# Altium schematic sheet lv_power_motor.SchDoc
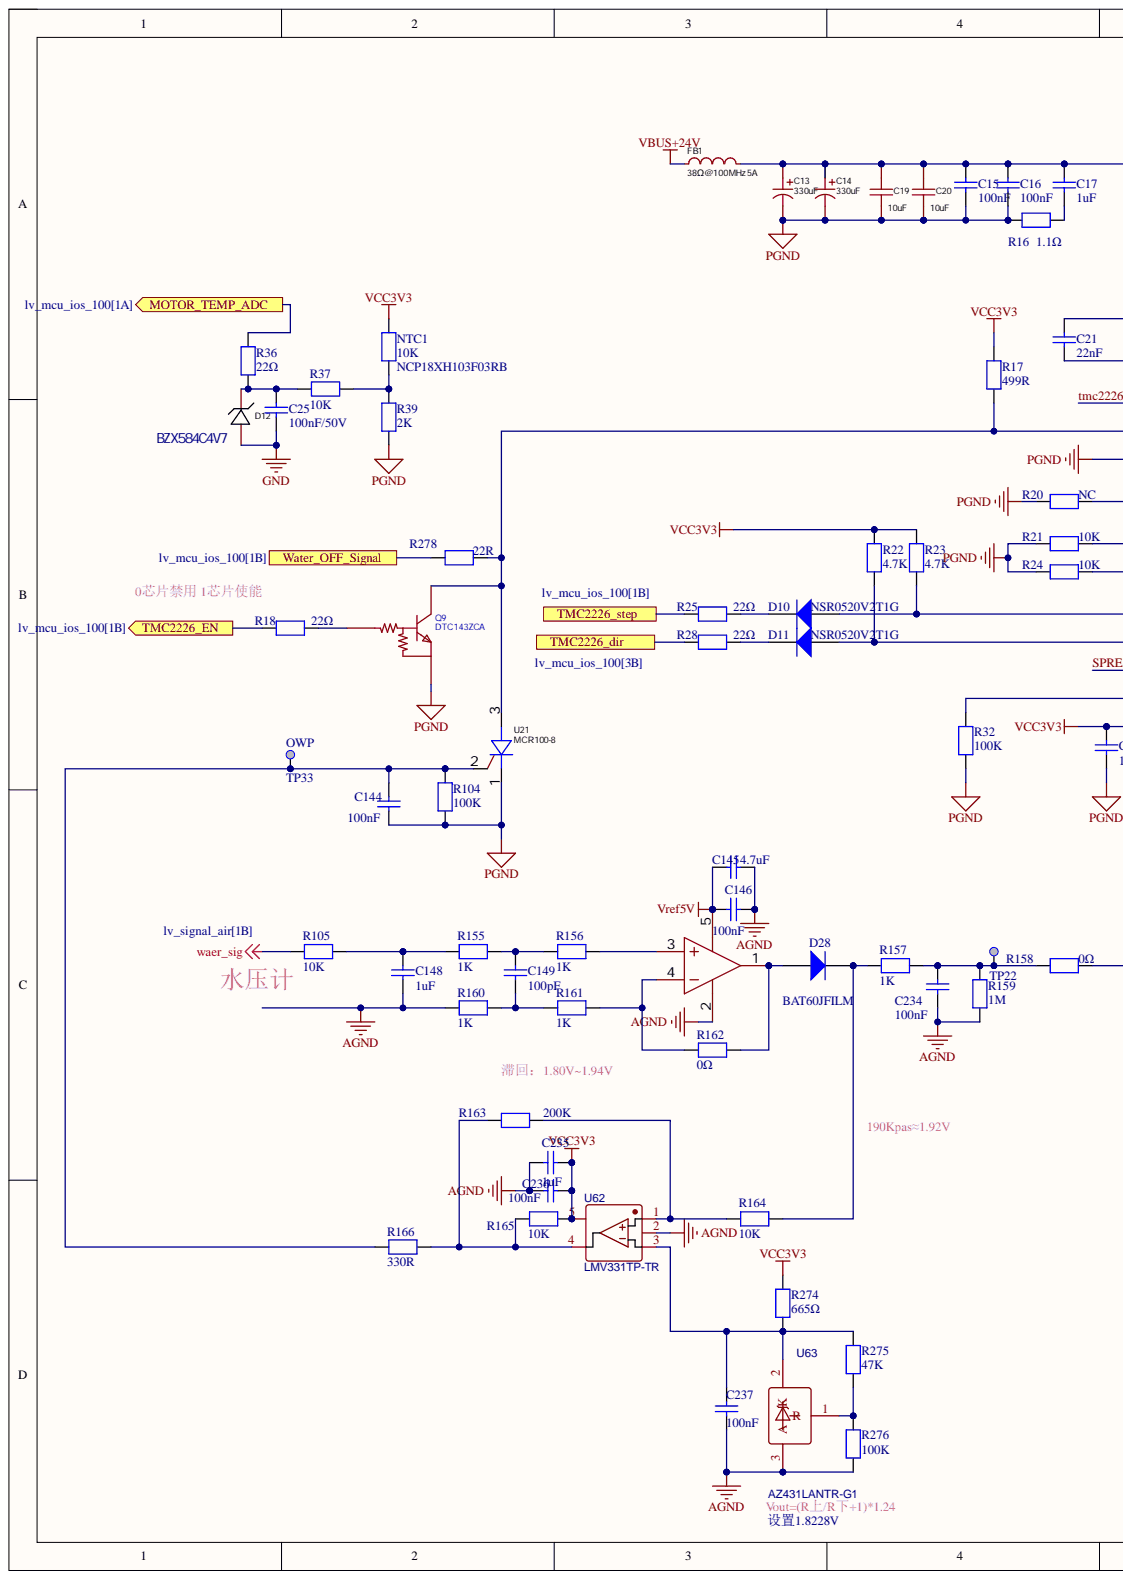
[diagram p0: lv_power_motor.SchDoc, left]
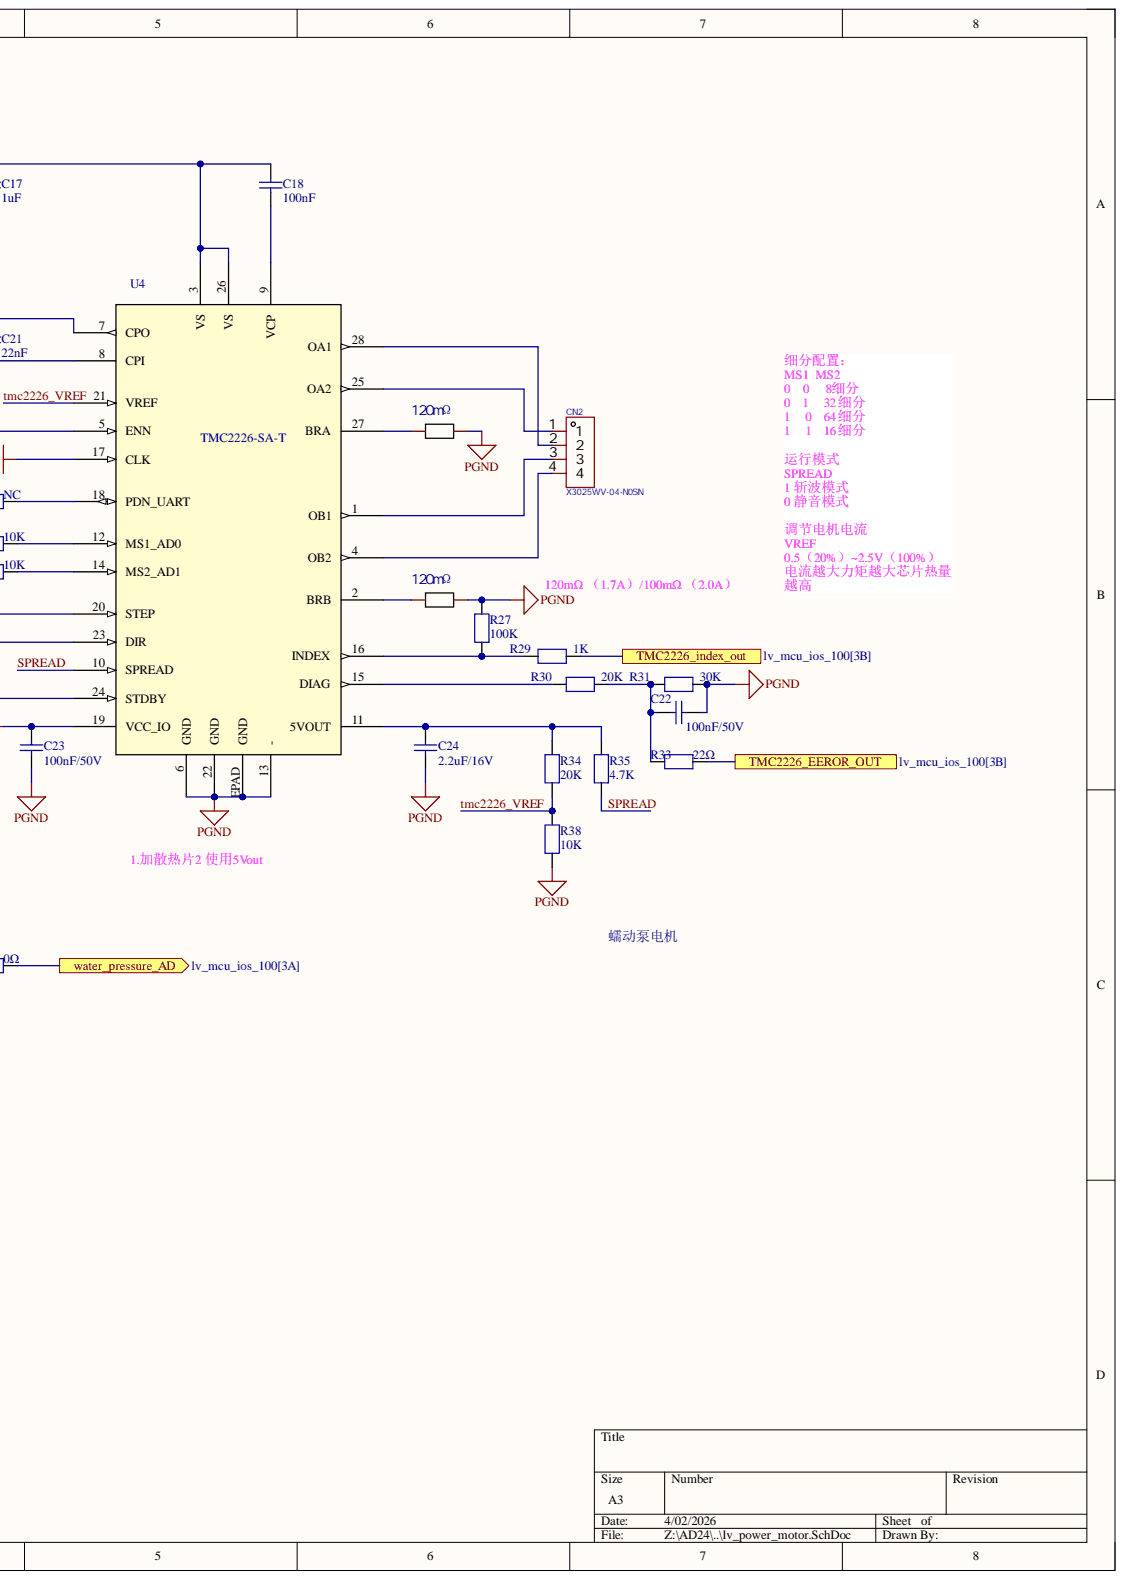
[diagram p1: lv_power_motor.SchDoc, right]

## Parts
C13  330uF  [CAP-SMD_BD10.0-L10.3-W10.3-LS10.9-FD]
C14  330uF  [CAP-SMD_BD10.0-L10.3-W10.3-LS10.9-FD]
C15  100nF  [C0603]
C16  100nF  [C0603]
C17  1uF  [C1210]
C18  100nF  [C0603]
C19  10uF  [C2220]
C20  10uF  [C2220]
C21  22nF  [C0603]
C22  100nF/50V  [C0402]
C23  100nF/50V  [C0402]
C24  2.2uF/16V  [C0603]
C25  100nF/50V  [C0402]
C144  100nF  [C0402]
C145  4.7uF  [C0603]
C146  100nF  [C0402]
C148  1uF  [C0603]
C149  100pF  [C0402]
C234  100nF  [C0402]
C235  value 1uF  [C0603]
C236  100nF  [C0402]
C237  100nF  [C0402]
CN2  X3025WV-04-N0SN  [CONN-TH_4P-P3.00_X3025WV-04-N0SN]
D10  NSR0520V2T1G  [SOD-523F_L1.2-W0.8-LS1.7-RD]
D11  NSR0520V2T1G  [SOD-523F_L1.2-W0.8-LS1.7-RD]
D12  BZX584C4V7  [SOD-523F_L1.2-W0.8-LS1.7-RD]
D28  BAT60JFILM  [DIO_SOD323]
FB1  LCSC Part Name: 38Ω@100MHz 5A  [L1206]  (hidden comment: PZ3216D380-5R0TF)
NTC1  NCP18XH103F03RB  value 10K  [R0603]
Q9  DTC143ZCA  [SOT-416_L1.6-W0.8-P1.00-LS1.6-BR]
R16  1.1Ω  [R0603]
R17  499R  [R0603]
R18  22Ω  [R0402]
R19  120mΩ  [RES-SMD_L6.4-W3.2_C500733]
R20  NC  [R0402]
R21  10K  [R0402]
R22  4.7K  [R0402]
R23  4.7K  [R0402]
R24  10K  [R0402]
R25  22Ω  [R0402]
R26  120mΩ  [RES-SMD_L6.4-W3.2_C500733]
R27  100K  [R0402]
R28  22Ω  [R0402]
R29  1K  [R0402]
R30  20K  [R0402]
R31  30K  [R0402]
R32  100K  [R0603]
R33  22Ω  [R0402]
R34  20K  [R0402]
R35  4.7K  [R0402]
R36  22Ω  [R0402]
R37  10K  [R0402]
R38  10K  [R0402]
R39  2K  [R0402]
R104  100K  [R0402]
R105  10K  [R0402]
R155  1K  [R0402]
R156  1K  [R0402]
R157  1K  [R0402]
R158  0Ω  [R0402]
R159  1M  [R0402]
R160  1K  [R0402]
R161  1K  [R0402]
R162  0Ω  [R0402]
R163  200K  [R0402]
R164  10K  [R0402]
R165  10K  [R0402]
R166  330R  [R0402]
R274  665Ω  [R0402]
R275  47K  [R0402]
R276  100K  [R0402]
R278  22R  [R0402]
TP22  WaterADC  [TP_SMD_1MM]  (value not drawn)  (no BOM)
TP33  OWP  [TP_SMD_1MM]  (no BOM)
U4  TMC2226-SA-T  [HTSSOP_26-SA-T_TRI]
U21  MCR100-8  [SOT-23-3_L2.9-W1.3-P1.90-LS2.4-BR]
U60  TLV271CDBVR  [SOT-23-5_L3.0-W1.7-P0.95-LS2.8-BR]  (value not drawn)
U62  LMV331TP-TR  [SOT-23-5_L3.0-W1.7-P0.95-LS2.8-BR]
U63  AZ431LANTR-G1  [SOT-23-3_L2.9-W1.6-P1.90-LS2.8-BR]

## Ports
MOTOR_TEMP_ADC, TMC2226_dir, TMC2226_EEROR_OUT, TMC2226_EN, TMC2226_index_out, TMC2226_step, Water_OFF_Signal, water_pressure_AD

## Nets
AGND: C145.1 C146.2 C148.2 C234.1 C235.1 C236.2 C237.2 R159.1 R160.1 R276.1 U60.2 U62.2 U63.3
GND: C25.2 D12.2
PGND: C13.2 C14.2 C15.1 C16.1 C19.2 C20.2 C22.2 C23.2 C24.2 C144.2 Q9.2 R16.2 R19.2 R20.2 R21.1 R24.1 R26.2 R27.1 R31.1 R32.1 R38.2 R39.1 R104.1 U4.6(GND) U4.13(-) U4.17(CLK) U4.22(GND) U4.EPAD(GND) U21.1
VBUS+24V: FB1.1
VCC3V3: C23.1 C235.2 C236.1 NTC1.2 R17.2 R22.1 R23.1 R165.2 R274.2 U4.19(VCC_IO) U62.5
Vref5V: C145.2 C146.1 U60.5
waer_sig: R105.1
MOTOR_TEMP_ADC: R36.2 ; port MOTOR_TEMP_ADC
SPREAD: R35.2 U4.10(SPREAD)
TMC2226_dir: R28.1 ; port TMC2226_dir
TMC2226_EEROR_OUT: R33.2 ; port TMC2226_EEROR_OUT
TMC2226_EN: R18.1 ; port TMC2226_EN
TMC2226_index_out: R29.1 ; port TMC2226_index_out
TMC2226_step: R25.1 ; port TMC2226_step
tmc2226_VREF: R34.2 R38.1 U4.21(VREF)
Water_OFF_Signal: R278.2 ; port Water_OFF_Signal
water_pressure_AD: R158.1 ; port water_pressure_AD
NetC13_1: C13.1 C14.1 C15.2 C16.2 C17.2 C18.2 C19.1 C20.1 FB1.2 U4.3(VS) U4.26(VS)
NetC17_1: C17.1 R16.1
NetC18_1: C18.1 U4.9(VCP)
NetC21_1: C21.1 U4.8(CPI)
NetC21_2: C21.2 U4.7(CPO)
NetC22_1: C22.1 R30.1 R31.2 R33.1
NetC24_1: C24.1 R34.1 R35.1 U4.11(5VOUT)
NetC25_1: C25.1 D12.1 R36.1 R37.2
NetC144_1: C144.1 R104.2 R166.1 TP33.1 U21.2
NetC148_1: C148.1 R105.2 R155.1
NetC149_1: C149.1 R155.2 R156.1
NetC149_2: C149.2 R160.2 R161.1
NetC234_2: C234.2 R157.2 R158.2 R159.2 TP22.1
NetC237_1: C237.1 R274.1 R275.2 U62.3 U63.2
NetCN2_1: CN2.1 U4.25(OA2)
NetCN2_2: CN2.2 U4.28(OA1)
NetCN2_3: CN2.3 U4.1(OB1)
NetCN2_4: CN2.4 U4.4(OB2)
NetD10_1: D10.1 R25.2
NetD10_2: D10.2 R23.2 U4.20(STEP)
NetD11_1: D11.1 R28.2
NetD11_2: D11.2 R22.2 U4.23(DIR)
NetD28_1: D28.1 R162.1 U60.1
NetD28_2: D28.2 R157.1 R164.1
NetNTC1_1: NTC1.1 R37.1 R39.2
NetQ9_1: Q9.1 R18.2
NetQ9_3: Q9.3 R17.1 R278.1 U4.5(ENN) U21.3
NetR19_1: R19.1 U4.27(BRA)
NetR20_1: R20.1 U4.18(PDN_UART)
NetR21_2: R21.2 U4.12(MS1_AD0)
NetR24_2: R24.2 U4.14(MS2_AD1)
NetR26_1: R26.1 U4.2(BRB)
NetR27_2: R27.2 R29.2 U4.16(INDEX)
NetR30_2: R30.2 U4.15(DIAG)
NetR32_2: R32.2 U4.24(STDBY)
NetR156_2: R156.2 U60.3
NetR161_2: R161.2 R162.2 U60.4
NetR163_1: R163.1 R164.2 U62.1
NetR163_2: R163.2 R165.1 R166.2 U62.4
NetR275_1: R275.1 R276.2 U63.1

## Library data of the parts
C13 C14 = HV1V337M1010PZ: 330uF, 35V, 20%; Manufacturer: HONOR(荣誉); Add into BOM: yes; L: 10mm; Component Kind: Standard; Convert to PCB: yes; Datasheet: https://atta.szlcsc.com/upload/public/pdf/source/20240802/61683F5000C7CFED5606ABBE3CFBE0F5.pdf; Device: HV1V337M1010PZ; JLCPCB Part Class: Extended Part; LCSC Part Name: 330uF ±20% 35V; Library Name: HiPin_Library_AD.IntLib; Manufacturer Part: HV1V337M1010PZ; Operating Temperature: -55℃~+105℃; Rated Voltage: 35V; Supplier: LCSC; Supplier Footprint: SMD,D10xL10mm; Supplier Part: C5246558; Symbol: HV1V337M1010PZ; Tolerance: ±20%; Value: 330uF; φD: 10mm
C15 C16 C18 = Capacitor_1: 50V, X7R, 10%; Manufacturer: muRata; Manufacturer_Part_Number: GRM188R71H104KA93D; Component Kind: Standard; ComponentLink1Description: Yageo_Capacitor_X5R-series.pdf; ComponentLink1URL: http://tunmcdsvn.tun.st.com/Component_Datasheet_Library/Trunk/Capacitors/Yageo_Multilayer_capacitor_X5R_series_100pF_to_100uF; Library Name: HiPin_Library_AD.IntLib
C17 = Capacitor_3: 50V, X7R, 10%; Manufacturer: YAGEO; Manufacturer_Part_Number: CC1210KKX7R9BB105; Component Kind: Standard; Library Name: HiPin_Library_AD.IntLib
C19 C20 = FS55X106K500EGG: Manufacturer: PSA(信昌电陶); Add into BOM: yes; Temperature Coefficient: X7R; Tolerance: ±10%; Voltage Rated: 50V; Component Kind: Standard; Convert to PCB: yes; Datasheet: https://atta.szlcsc.com/upload/public/pdf/source/20190723/C381466_B1CCDB289216E7EE775C98769E222CFD.pdf; Device: FS55X106K500EGG; JLCPCB Part Class: Extended Part; LCSC Part Name: 10uF ±10% 50V; Library Name: HiPin_Library_AD.IntLib; Manufacturer Part: FS55X106K500EGG; Supplier: LCSC; Supplier Footprint: 2220; Supplier Part: C153034; Symbol: FS55X106K500EGG; Value: 10uF
C21 = Capacitor_1: 50V, X7R, 10%; Manufacturer: muRata; Manufacturer_Part_Number: GCJ188R71H223KA01D; Component Kind: Standard; ComponentLink1Description: Yageo_Capacitor_X5R-series.pdf; ComponentLink1URL: http://tunmcdsvn.tun.st.com/Component_Datasheet_Library/Trunk/Capacitors/Yageo_Multilayer_capacitor_X5R_series_100pF_to_100uF; Library Name: HiPin_Library_AD.IntLib
C22 C23 C25 = Capacitor_2: 50V, X7R , 10%; Manufacturer: muRata; Manufacturer_Part_Number: GRM155R71H104KE14D; Component Kind: Standard; ComponentLink1Description: Datasheet; ComponentLink1URL: http://tunmcdsvn.tun.st.com/Component_Datasheet_Library/Trunk/Capacitors/Yageo_Multilayer_capacitor_X5R_series_100pF_to_100uF; Library Name: HiPin_Library_AD.IntLib
C24 = Capacitor_2: 16V, X7R , 10%; Manufacturer: YAGEO; Manufacturer_Part_Number: CC0603KRX7R7BB225; Component Kind: Standard; ComponentLink1Description: Datasheet; ComponentLink1URL: http://tunmcdsvn.tun.st.com/Component_Datasheet_Library/Trunk/Capacitors/Yageo_Multilayer_capacitor_X5R_series_100pF_to_100uF; Library Name: HiPin_Library_AD.IntLib
C144 C236 C237 = Capacitor: 50V, 10%, X7R; Internal_Part_Number: MCD-00003; Manufacturer: muRata; Manufacturer_Part_Number: GRM155R71H104KE14D; Component Kind: Standard; ComponentLink1Description: Datasheet; ComponentLink1URL: http://tunmcdsvn.tun.st.com/Component_Datasheet_Library/Trunk/Capacitors/Yageo_Capacitor_NP0_16V-to-50V; Library Name: HiPin_Library_AD.IntLib; Package: C0402; Value: 100nF
C145 = Capacitor_1: 16V, X7S, 10%; Internal_Part_Number: MCD-00009; Manufacturer: muRata; Manufacturer_Part_Number: GRM188C71C475KE21D; Component Kind: Standard; ComponentLink1Description: Yageo_Capacitor_X5R-series.pdf; ComponentLink1URL: http://tunmcdsvn.tun.st.com/Component_Datasheet_Library/Trunk/Capacitors/Yageo_Multilayer_capacitor_X5R_series_100pF_to_100uF; Library Name: HiPin_Library_AD.IntLib; Package: C0603; Value: 4.7uF
C146 C234 = Capacitor: 50V, 5%, X7R; Manufacturer: muRata; Manufacturer_Part_Number: GRM155R71H104KE14D; Component Kind: Standard; ComponentLink1Description: Datasheet; ComponentLink1URL: http://tunmcdsvn.tun.st.com/Component_Datasheet_Library/Trunk/Capacitors/Yageo_Capacitor_NP0_16V-to-50V; Library Name: HiPin_Library_AD.IntLib
C148 = Capacitor_1: 50V, X5R, 10%; Manufacturer: muRata; Manufacturer_Part_Number: GRM188R61H105KAALD; Component Kind: Standard; ComponentLink1Description: Yageo_Capacitor_X5R-series.pdf; ComponentLink1URL: http://tunmcdsvn.tun.st.com/Component_Datasheet_Library/Trunk/Capacitors/Yageo_Multilayer_capacitor_X5R_series_100pF_to_100uF; Library Name: HiPin_Library_AD.IntLib
C149 = Capacitor: 50V, 5%, C0G; Manufacturer: muRata; Manufacturer_Part_Number: GRM1555C1H101JA01D; Component Kind: Standard; ComponentLink1Description: Datasheet; ComponentLink1URL: http://tunmcdsvn.tun.st.com/Component_Datasheet_Library/Trunk/Capacitors/Yageo_Capacitor_NP0_16V-to-50V; Library Name: HiPin_Library_AD.IntLib
C235 = MCD-00010: Capacitor, MLCC, 1uF, 16V, X5R, 10%, 0603, T=0,8mm; Manufacturer: YAGEO; Manufacturer_Part_Number: CC0603KRX5R7BB105; Database Table Name: MCD_PARTS$; Initial_Board_Reference: MB1274; Provided_By: Subcon; Component Kind: Standard; ComponentLink1Description: Yageo_Capacitor_X5R-series.pdf; ComponentLink1URL: http://tunmcdsvn.tun.st.com/Component_Datasheet_Library/Trunk/Capacitors/Yageo_Multilayer_capacitor_X5R_series_100pF_to_100uF; ECCN_EU: n/a; ECCN_US: n/a; Internal_Part_Number: MCD-00010; Library Name: MCD_PARTS_database.DbLib; Package: C0603; Part_Type: Capacitor; Status: Released
CN2 = X3025WV-04-N0SN: 插针结构1x4P,间距3mm.安装方式直插  配套:针座X3025WV-04-N0SN ,胶壳X3025HM-04-N2 ,端子X3025TF-PSN; Manufacturer: XKB Connection(中国星坤); Add into BOM: yes; Current Rating: 5A; Number of PINs Per Row: 4; Pins Structure: 1x4P; Pitch: 3mm; Component Kind: Standard; Contact Material: 黄铜; Contact Plating: 锡; Convert to PCB: yes; Datasheet: https://item.szlcsc.com/datasheet/X3025WV-04-N0SN/639847.html; Device: X3025WV-04-N0SN; JLCPCB Part Class: Extended Part; LCSC Part Name: 1x4P 间距:3mm 直插; Library Name: HiPin_Library_AD.IntLib; Manufacturer Part: X3025WV-04-N0SN; Mounting Type: 直插; Number of Pins: 4P; Number of Rows: 1; Operating Temperature: -; PCB3D: CONN-TH_4P-P3.00_X3025WV-04-N0SN; Reference Series: -; Row Spacing: -; Supplier: LCSC; Supplier Footprint: 插件,P=3mm; Supplier Part: C609842; Symbol: X3025WV-04-N0SN
D10 D11 = 1N4148: Component Kind: Standard; Library Name: HiPin_Library_AD.IntLib
D12 = LM5Z3V6T1G: 4.8~5.4V, 200mW; Power Dissipation: 500mW; Component Kind: Standard; Library Name: HiPin_Library_AD.IntLib; Manufacturer Part: BZX584C4V7; Supplier Footprint: SOD-123; Tolerance: -
D28 = BAT60JFILM: Internal_Part_Number: MCD-00034; Manufacturer: STMICROELECTRONICS; Part_Type: Diode; ECCN_EU: NEC; ECCN_US: EAR99; Initial_Board_Reference: MB1274; Provided_By: Subcon; Component Kind: Standard; ComponentLink1Description: STM_Diode_BAT60JFILM-SOD323.pdf; ComponentLink1URL: http://tunmcdsvn.tun.st.com/Component_Datasheet_Library/Trunk/Diodes/STM_Diode_BAT60JFILM-SOD323; Description: BAT60JFILM; Library Name: HiPin_Library_AD.IntLib; Manufacturer_Part_Number: BAT60JFILM; Status: Released; Value: BAT60JFILM
FB1 = BLM31PG601SN1L: Manufacturer: Sunlord(顺络); Add into BOM: yes; Circuits: 1; Current Rating: 1.5A; DC Resistance: 80mΩ; Impedance @ Frequency: 600Ω@100MHz; Tolerance: ±25%; Component Kind: Standard; Convert to PCB: yes; Datasheet: https://atta.szlcsc.com/upload/public/pdf/source/20180326/C88997_FE7208E239BA92CF7577D85CF2703D30.pdf; Device: BLM31PG601SN1L; JLCPCB Part Class: Extended Part; Library Name: HiPin_Library_AD.IntLib; Manufacturer Part: PZ3216D380-5R0TF; Supplier: LCSC; Supplier Footprint: 1206; Supplier Part: C16902; Symbol: BLM31PG601SN1L
NTC1 = RES: 1% 3380K; Component Kind: Standard; Library Name: HiPin_Library_AD.IntLib
Q9 = DTC043ZEBTL: NPN 三极管; Manufacturer: ROHM(罗姆); Add into BOM: yes; Collector Current (Ic): 100mA; Collector-Emitter Breakdown Voltage (Vceo): 50V; DC Current Gain (hFE@Ic,Vce): 80@5mA,10V; Input Resistor: 4.7kΩ; Input Voltage (VI(on)@Ic,Vce): 1.1V@5mA,0.3V; Output Voltage (VO(on)@Io/Ii): 150mV@5mA,0.5mA; Power Dissipation (Pd): 150mW; Resistor Ratio: 10; Component Kind: Standard; Convert to PCB: yes; Datasheet: https://atta.szlcsc.com/upload/public/pdf/source/20230825/AFF9D3CF4DD067520C5D7C04408FCBF2.pdf; Device: DTC043ZEBTL; JLCPCB Part Class: Extended Part; LCSC Part Name: 耐压:50V 电流:100mA; Library Name: HiPin_Library_AD.IntLib; Manufacturer Part: DTC043ZEBTL; Operating Temperature: -55℃~+150℃; PCB3D: SOT-416-3P_L1.6-W0.8-H0.9-LS1.6-P1.00; Supplier: LCSC; Supplier Footprint: SOT-416FL; Supplier Part: C96459; Symbol: DTC043ZEBTL; Transistor Type: -
R16-R18 R20 R22 R23 R25 R27-R36 R39 R104 R155-R163 R166 R278 = RES: 1%; Value: 10K; Component Kind: Standard; Library Name: HiPin_Library_AD.IntLib
R19 R26 = PA2512FKE070R005E: 120mΩ，1W，1%; Manufacturer: YAGEO(国巨); Manufacturer Part: RL2512JK-070R12L; Component Kind: Standard; Library Name: HiPin_Library_AD.IntLib; PCB3D: RES-SMD_L6.4-W3.2_C500733
R21 R24 R37 R38 R105 R164 R165 = RES: 1%; Component Kind: Standard; Library Name: HiPin_Library_AD.IntLib; Value: 10K
R274-R276 = RES: Resistor; Component Kind: Standard; Library Name: HiPin_Library_AD.IntLib
TP22 TP33 = MCD-00074: Test Point 1mm; Part_Type: Mechanical; Database Table Name: MCD_PARTS$; ComponentLink1Description: Datasheet; ComponentLink1URL: http://na; ECCN_EU: n/a; ECCN_US: n/a; Internal_Part_Number: MCD-00074; Library Name: MCD_PARTS_database.DbLib; Manufacturer: n/a; Manufacturer_Part_Number: n/a; Provided_By: n/a; Status: Released
U4 = TMC2226-SA-T: No Description Available; Mfr_Name: Analog Devices Inc; Component Kind: Standard; Library Name: HiPin_Library_AD.IntLib; RefDes: RefDes; Type: Type
U21 = MCR100-8_C5184437: Manufacturer: HXY MOSFET(华轩阳电子); Add into BOM: yes; Average Gate Power Dissipation (PG(AV)): 100mW; Gate Trigger   Voltage (Vgt): 800mV; Gate Trigger Current(Igt): 60uA; Holding Current (Ih): 5mA; Peak non-repetitive surge current (Itsm@f): 8A; Peak Repetitive Off?State Voltage (Vdrm): 600V; RMS On-State Current(It (rms)): 800mA; SCR Type: 单向可控硅; Voltage - On State (Vtm): 1.7V; Component Kind: Standard; Convert to PCB: yes; Datasheet: https://atta.szlcsc.com/upload/public/pdf/source/20240902/7358CD860A43FFBE3B53FCDEB35A6F8C.pdf; Device: MCR100-8_C5184437; JLCPCB Part Class: Extended Part; LCSC Part Name: 单向 Vgt: 800mV IT:0.8A Vdrm: 600V; Library Name: HiPin_Library_AD.IntLib; Manufacturer Part: MCR100-8; Operating Temperature: -; PCB3D: SOT-23-3_L2.9-W1.3-P1.90-LS2.4-BR-CW; Supplier: LCSC; Supplier Footprint: SOT-23; Supplier Part: C5184437; Symbol: MCR100-8_C5184437
U60 = TLV271CDBVR: Manufacturer: TI(德州仪器); Add into BOM: yes; Current - Input Bias(Ib): 1pA; Gain Bandwidth Product(GBP): 3MHz; Number of Circuits: 单路; Output Current: 8mA; Slew Rate(SR): 2.6V/us; Supply Current: 625uA; Component Kind: Standard; Convert to PCB: yes; Datasheet: https://www.ti.com/cn/lit/gpn/tlv271; Device: TLV271CDBVR; JLCPCB Part Class: Extended Part; LCSC Part Name: TLV271CDBVR; Library Name: HiPin_Library_AD.IntLib; Manufacturer Part: TLV271CDBVR; Operating Temperature: 0℃~+70℃; Output Type: -; Rail to Rail: -; Supplier: LCSC; Supplier Footprint: SOT-23-5; Supplier Part: C131948; Symbol: TLV271CDBVR
U62 = LMV331TP-TR: 比较器; BOM_Manufacturer: 3PEAK; Contributor: LCSC; BOM_JLCPCB Part Class: Extended Part; BOM_Manufacturer Part: LMV331TP-TR; BOM_Supplier: LCSC; BOM_Supplier Part: C108095; Component Kind: Standard; Library Name: HiPin_Library_AD.IntLib; spicePre: U; spiceSymbolName: LMV331TP-TR
U63 = TL431: BOM_Manufacturer: JSMSEMI; Contributor: LCSC; BOM_JLCPCB Part Class: Extended Part; BOM_Manufacturer Part: TL431; BOM_Supplier: LCSC; BOM_Supplier Part: C963380; Component Kind: Standard; Library Name: HiPin_Library_AD.IntLib; spicePre: U; spiceSymbolName: TL431_C963380
